# Altium schematic sheet POWER_SUPPLY.SchDoc
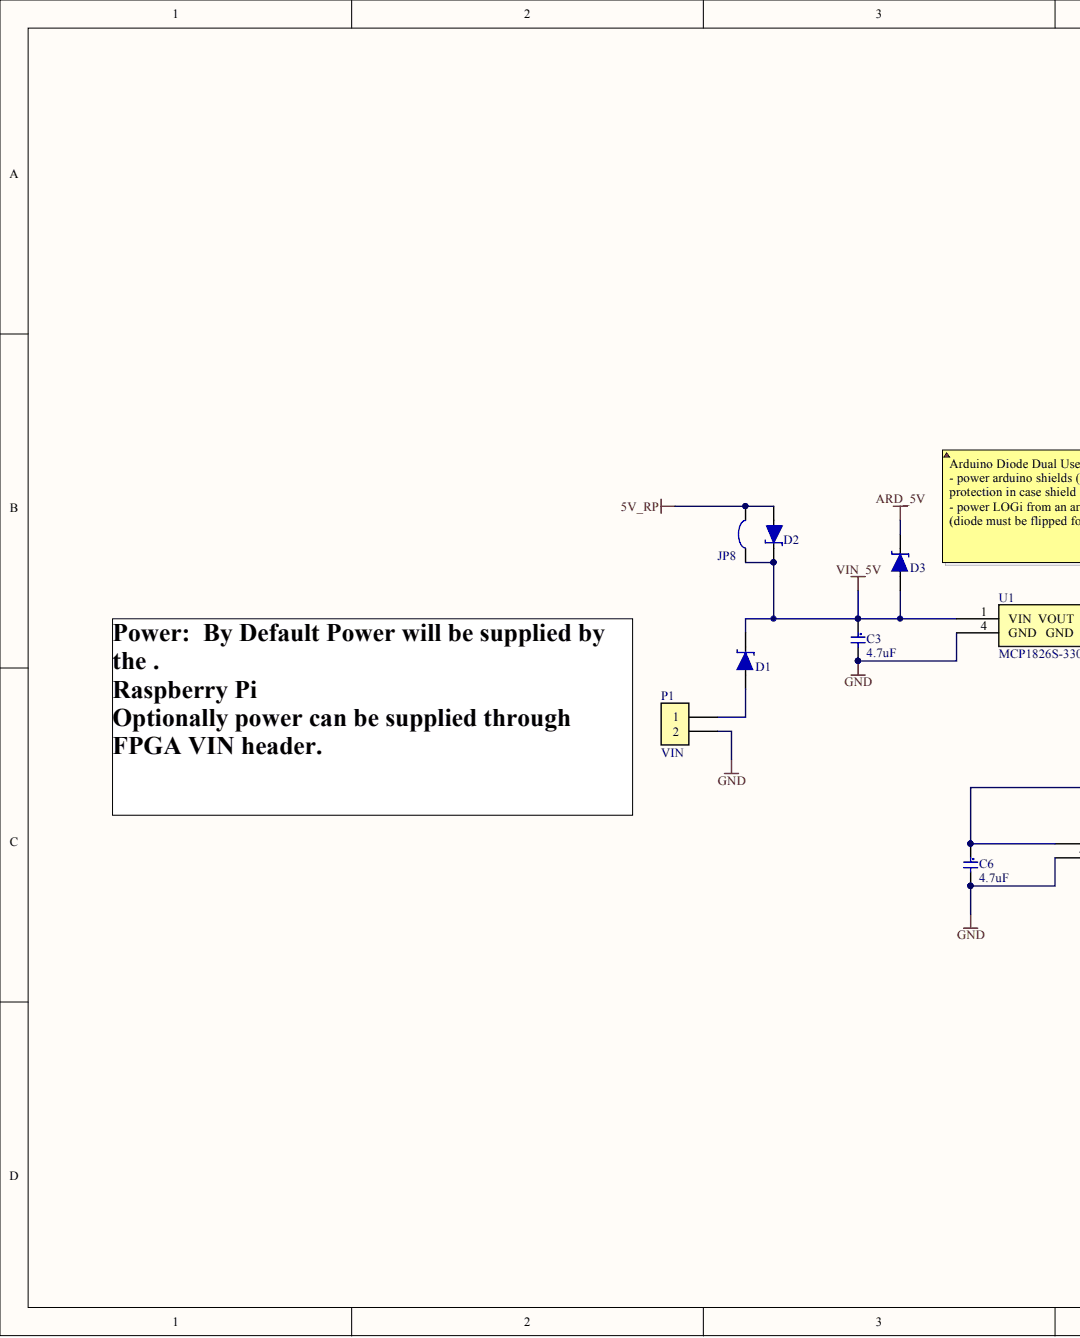
[diagram p0: POWER_SUPPLY.SchDoc, left]
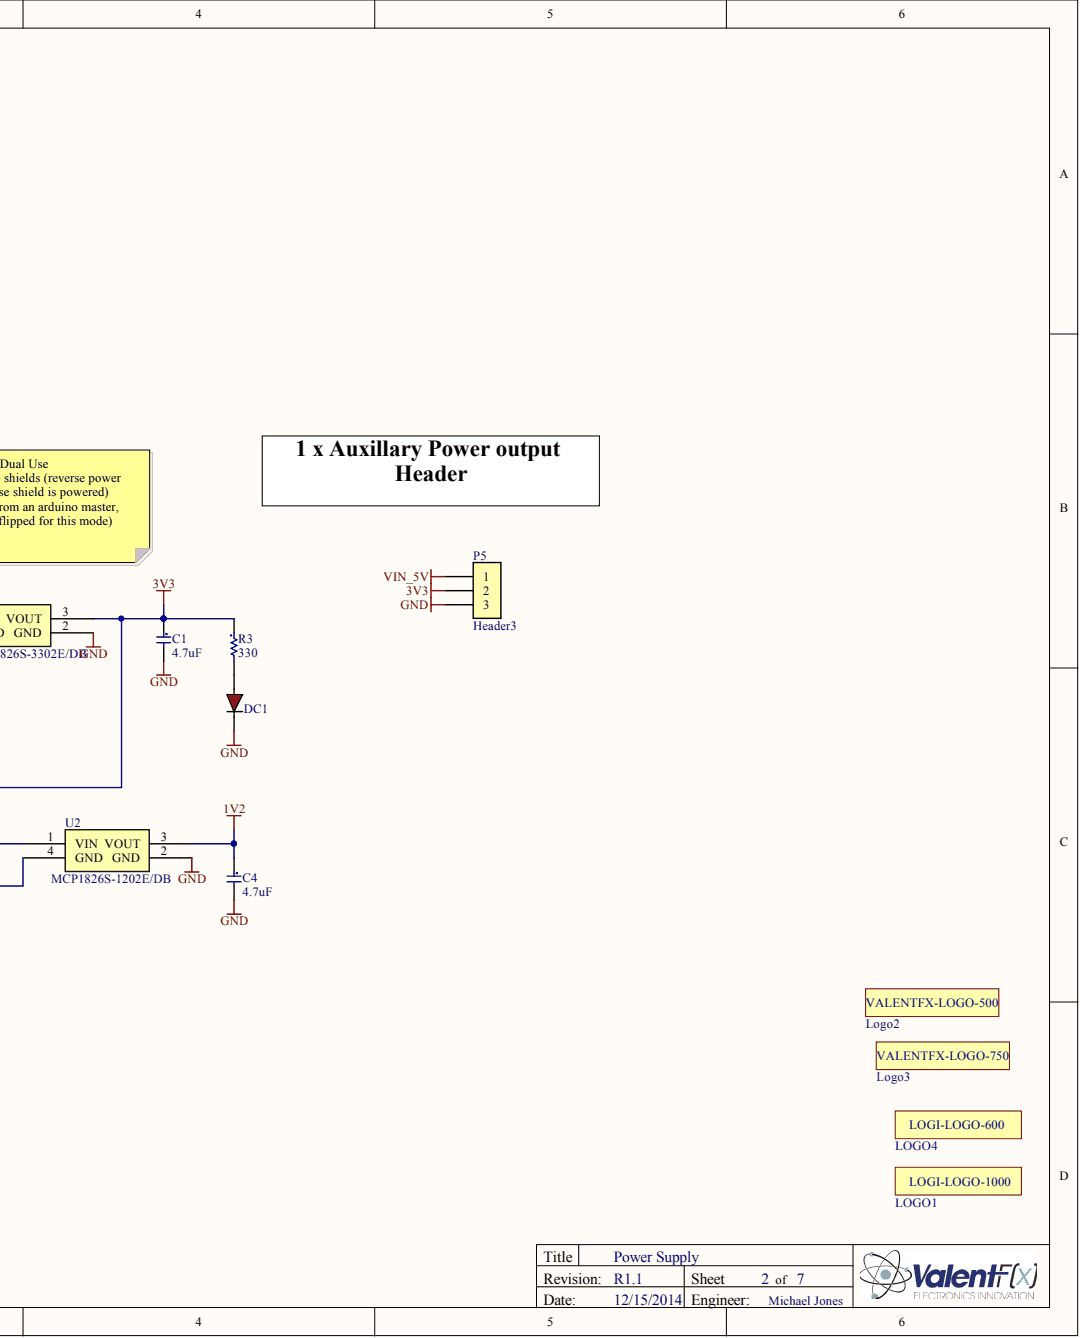
[diagram p1: POWER_SUPPLY.SchDoc, right]

## Parts
C1  4.7uF  [C0603 - Marked]
C3  4.7uF  [C0603 - Marked]
C4  4.7uF  [C0603 - Marked]
C6  4.7uF  [C0603 - Marked]
D1  RB161M-20TR  [SOD-123]  (value not drawn)
D2  RB161M-20TR  [SOD-123]  (value not drawn)
D3  RB161M-20TR  [SOD-123]  (value not drawn)
DC1  598-8010-107F  [LED_0603 - RED]  (value not drawn)
JP8  Solder Jumper  [SOLDER_JUMPER]  (value not drawn)  (no BOM)
LOGO1  LOGI-1000  [LOGI-1000-SILK]  (value not drawn)  (no BOM)
Logo2  Valent Logo 500  [VALENTFX_500-SolderMask]  (value not drawn)  (no BOM)
Logo3  Valent Logo 750  [VALENTFX_750-SolderMask]  (value not drawn)  (no BOM)
LOGO4  LOGI-600  [LOGI-600-SILK]  (value not drawn)  (no BOM)
P1  VIN  [HEADER_2_40_100 THRU SIP]  (no BOM)
P5  Header3  [HEADER_3_40_100 THRU SIP]  (no BOM)
R3  330  [R0603]
U1  MCP1826S-3302E/DB  [SOT223]
U2  MCP1826S-1202E/DB  [SOT223]

## Nets
1V2: C4.1 U2.3(VOUT)
3V3: C1.1 C6.1 P5.2 R3.1 U1.3(VOUT) U2.1(VIN)
5V_RP: D2.2 JP8.1
ARD_5V: D3.1
GND: C1.2 C3.2 C4.2 C6.2 DC1.C P1.2 P5.3 U1.2(GND) U1.4(GND) U2.2(GND) U2.4(GND)
VIN_5V: C3.1 D1.1 D2.1 D3.2 JP8.2 P5.1 U1.1(VIN)
NetD1_2: D1.2 P1.1
NetDC1_A: DC1.A R3.2

## Library data of the parts
C1 C3 C4 C6 = C0603 - GRM188R60J475KE19D: 4.7uF 6.3volts X5R 10% (MLCC) - SMD/SMT 0603; Manufacturer: Murata Electronics North America; Capacitance: 4.7µF; Voltage - Rated: 6.3V; Applications: General Purpose; Category: Capacitors; Component Kind: Standard; ComponentLink1Description: http://search.murata.co.jp/Ceramy/image/img/PDF/ENG/GRM188R60J475KE19.pdf; ComponentLink1URL: http://search.murata.co.jp/Ceramy/image/img/PDF/ENG/GRM188R60J475KE19.pdf; Features: -; Height - Seated (Max): -; Lead Spacing: -; Lead Style: -; Library Name: mCapacitors.SchLib; Manufacturer Part Number: GRM188R60J475KE19D; Mounting Type: Surface Mount, MLCC; Operating Temperature: -55°C ~ 85°C; Package / Case: 0603 (1608 Metric); Packaging: Cut Tape (CT); Pricing 1: 1=0.19, 10=0.132, 50=0.076, 100=0.0627, 250=0.05284, 500=0.04426, 1000=0.03633 (USD); Ratings: -; RoHS: RoHS Compliant; Size / Dimension: 0.063" L x 0.031" W (1.60mm x 0.80mm); Stock 1: 614848; Supplier 1: Digi-Key; Supplier Part Number 1: 490-3297-1-ND; Temperature Coefficient: X5R; Thickness (Max): 0.035" (0.90mm); Tolerance: ±10%; Value: 4.7uF
D1-D3 = RB161M-20TR: DIODE SCHOTTKY 25V 1A SOD123; Manufacturer: Diodes Inc; Manufacturer Part Number: Rohm Semiconductor; Source Part Number: RB161M-20CT-ND; Current - Reverse Leakage @ Vr: 700µA @ 20V; Speed: Fast Recovery =< 500ns, > 200mA (Io); Voltage - DC Reverse (Vr) (Max): 20V; Voltage - Forward (Vf) (Max) @ If: 350mV @ 1A; Capacitance @ Vr, F: -; Category: Discrete Semiconductor Products; Component Kind: Standard; ComponentLink1Description: http://www.rohm.com/products/databook/di/pdf/rb161m-20.pdf; ComponentLink1URL: http://www.rohm.com/products/databook/di/pdf/rb161m-20.pdf; Current - Average Rectified (Io): 1A; Diode Type: Schottky; Library Name: mDiode.SchLib; Mounting Type: Surface Mount; Package / Case: SOD-123F; Packaging: Cut Tape (CT); Pricing 1: 1=0.58, 25=0.4044, 100=0.3465, 250=0.29924, 500=0.25726, 1000=0.1995 (USD); Reverse Recovery Time (trr): -; RoHS: RoHS Compliant; Stock 1: 29589; Supplier 1: Digi-Key; Supplier Device Package: PMDU; Supplier Part Number 1: RB161M-20CT-ND; Value: RB161M-20TR; Source: Digikey
DC1 = LED0603 - 598-8010-107F: LED ALINGAP RED CLEAR 0603 SMD; Manufacturer: Dialight; Accept Replacement: YES - Water Clear; Current - Test: 20mA; Lens Style/Size: Rectangle with Flat Top, 1.1mm x 0.8mm; Millicandela Rating: 40mcd; Viewing Angle: 140°; Voltage - Forward (Vf) Typ: 2.2V; Wavelength - Dominant: 635nm; Category: Optoelectronics; Color: Red; Component Kind: Standard; ComponentLink1Description: http://media.digikey.com/pdf/Data%20Sheets/Dialight%20PDFs/598_Series_0603_Pkg.pdf; ComponentLink1URL: http://media.digikey.com/pdf/Data%20Sheets/Dialight%20PDFs/598_Series_0603_Pkg.pdf; Height: 0.70mm; Lens Type: Clear; Library Name: mDiode.SchLib; Luminous Flux @ Current - Test: -; Manufacturer Part Number: 598-8010-107F; Mounting Type: Surface Mount; Package / Case: 0603 (1608 Metric); Packaging: Digi-Reel®; Pricing 1: 1=0.45, 10=0.33, 25=0.264, 100=0.1749, 250=0.1452, 500=0.1056, 1000=0.0825 (USD); RoHS: RoHS Compliant; Size / Dimension: 1.60mm L x 0.80mm W; Stock 1: 37578; Supplier 1: Digi-Key; Supplier Part Number 1: 350-2029-6-ND; Wavelength - Peak: -
JP8 = Solder Jumper: Solder/Configuration Jumper; Library Name: mConnector.SchLib; Manufacturer: n/a; Manufacturer Part Number: n/a; ROHS Certificate: n/a; Source: n/a; Source Part Number: n/a
P1 = Header2: Header 2 pin; Library Name: mConnector.SchLib
P5 = Header3: Header  pin; Library Name: mConnector.SchLib
R3 = R0603 - ERJ-3GEYJ331V: Thick Film Resistors - SMD 0603 330ohms 5% Tol; Manufacturer: Panasonic; Power Rating: 100 mW; Temperature Coefficient: 200 PPM / C; Voltage Rating: 75 V; Case Code - in: 0603; Case Code - mm: 1608; Category: Thick Film Resistors - SMD; Component Kind: Standard; ComponentLink1Description: http://www.mouser.com/ds/2/315/AOA0000CE1-37422.pdf; ComponentLink1URL: http://www.mouser.com/ds/2/315/AOA0000CE1-37422.pdf; Dimensions: 0.8 mm W x 1.6 mm L x 0.45 mm H; Library Name: mResistors.SchLib; Manufacturer Part Number: ERJ-3GEYJ331V; Minimum Order Quantity: 1; Operating Temperature Range: - 55 C to + 155 C; Package / Case: 0603 (1608 metric); Packaging: Reel; Pricing 1: 1=0.108, 10=0.012, 100=0.005, 1000=0.004, 5000=0.003 (AUD); Product: Thick Film Resistors SMD; Reeling: True; Resistance: 330 Ohms; RoHS: RoHS Compliant By Exemption; RoHS - Mouser: E; Series: ERJ; Standard Pack Qty: 5000; Stock 1: 131470; Supplier 1: Mouser; Supplier Part Number 1: 667-ERJ-3GEYJ331V; Termination Style: SMD/SMT; Tolerance: 5 %; Value: 330
U1 = REG - MCP1826S-3302E/DB: LDO REG 1A 3.3V SOT223-3; Manufacturer: Microchip Technology; Current - Output: 1A (Min); Number of Regulators: 1; Regulator Topology: Positive Fixed; Voltage - Dropout (Typical): 0.25V @ 1A; Voltage - Input: Up to 6V; Category: Integrated Circuits (ICs); Component Kind: Standard; ComponentLink1Description: http://ww1.microchip.com/downloads/en/DeviceDoc/22057a.pdf; ComponentLink1URL: http://ww1.microchip.com/downloads/en/DeviceDoc/22057a.pdf; Current - Limit (Min): -; Library Name: mIC.SchLib; Manufacturer Part Number: MCP1826S-3302E/DB; Mounting Type: Surface Mount; Operating Temperature: -40°C ~ 125°C; Package / Case: TO-261-4, TO-261AA; Packaging: Tube; Pricing 1: 1=0.74, 10=0.68, 25=0.57, 100=0.52 (USD); RoHS: RoHS Compliant; Stock 1: 2041; Supplier 1: Digi-Key; Supplier Device Package: SOT-223-3; Supplier Part Number 1: MCP1826S-3302E/DB-ND; Value: MCP1826S-3302E/DB; Voltage - Output: 3.3V
U2 = MCP1826S-1202E/DB: IC LDO REG 1A 1.2V SOT223-3; Manufacturer: Microchip Technology; Current - Output: 1A (Min); Number of Regulators: 1; Regulator Topology: Positive Fixed; Voltage - Dropout (Typical): 0.25V @ 1A; Voltage - Input: Up to 6V; Category: Integrated Circuits (ICs); Component Kind: Standard; ComponentLink1Description: http://ww1.microchip.com/downloads/en/DeviceDoc/22057a.pdf; ComponentLink1URL: http://ww1.microchip.com/downloads/en/DeviceDoc/22057a.pdf; Current - Limit (Min): -; Library Name: eIC.SchLib; Manufacturer Part Number: MCP1826S-1202E/DB; Mounting Type: Surface Mount; Operating Temperature: -40°C ~ 125°C; Package / Case: TO-261-4, TO-261AA; Packaging: Tube; Pricing 1: 1=0.74, 10=0.68, 25=0.57, 100=0.52 (USD); RoHS: RoHS Compliant; Stock 1: 2041; Supplier 1: Digi-Key; Supplier Device Package: SOT-223-3; Supplier Part Number 1: MCP1826S-1202E/DB-ND; Value: MCP1826S-1202E/DB; Voltage - Output: 1.2V
LOGO1 = LOGI-1000: Library Name: mLogos.SchLib
Logo2 = Valent Logo 500: Library Name: mLogos.SchLib
Logo3 = Valent Logo 750: Library Name: mLogos.SchLib
LOGO4 = LOGI-600: Library Name: mLogos.SchLib
